# Altium schematic sheet Connectors 2.SchDoc("Connectors 2")
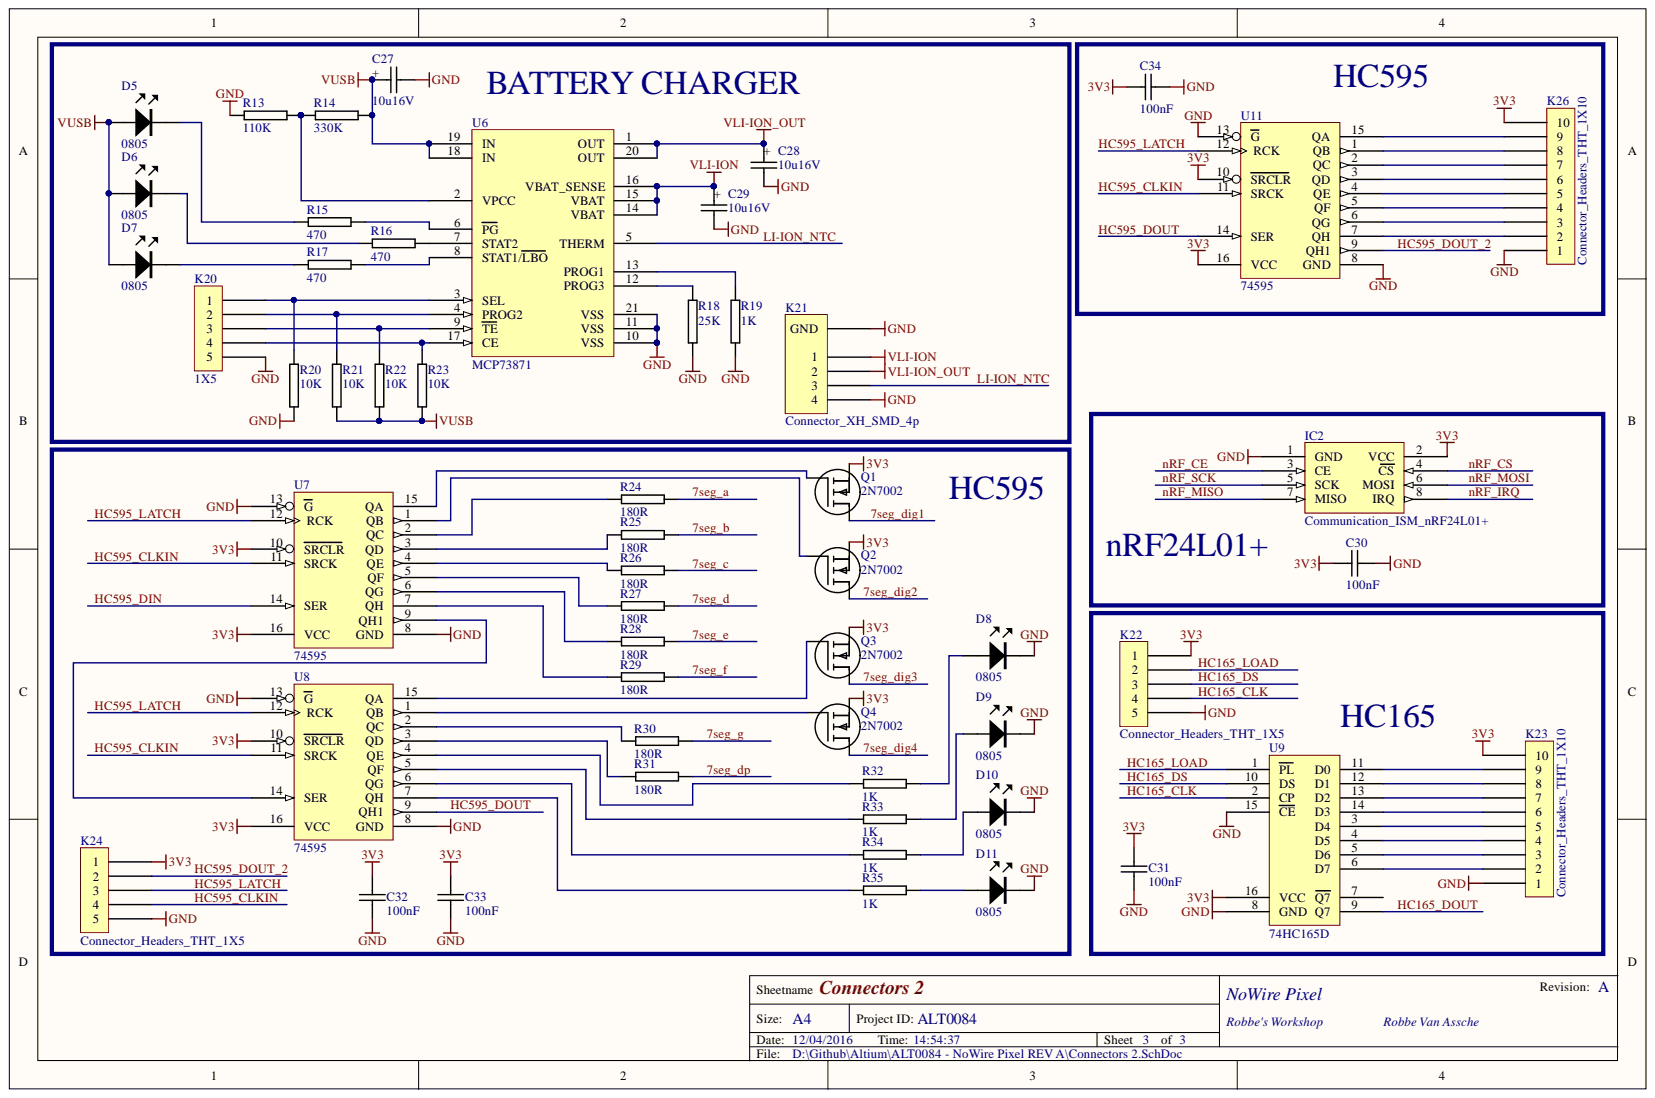
[diagram p0: Connectors 2.SchDoc]

## Parts
C27  10u16V  [1206C+_TANTAL-10u16V]
C28  10u16V  [1206C+_TANTAL-10u16V]
C29  10u16V  [1206C+_TANTAL-10u16V]
C30  100nF  [C0402]
C31  100nF  [C0402]
C32  100nF  [C0402]
C33  100nF  [C0402]
C34  100nF  [C0402]
D5  0805  [0805LED]
D6  0805  [0805LED]
D7  0805  [0805LED]
D8  0805  [0805LED]
D9  0805  [0805LED]
D10  0805  [0805LED]
D11  0805  [0805LED]
IC2  Communication_ISM_nRF24L01+  [nRF24L01+_COMBO]
K20  1X5  [HEADER-1X5]
K21  Connector_XH_SMD_4p  [2.54_4p_THT+SMD]
K22  Connector_Headers_THT_1X5  [HEADER-1X5]
K23  Connector_Headers_THT_1X10  [HEADER-1X10]
K24  Connector_Headers_THT_1X5  [HEADER-1X5]
K26  Connector_Headers_THT_1X10  [HEADER-1X10]
Q1  2N7002  [SOT23-GDS]
Q2  2N7002  Reel: Q2  [SOT23-GDS]
Q3  2N7002  [SOT23-GDS]
Q4  2N7002  [SOT23-GDS]
R13  110K  [R0402]
R14  330K  [R0402]
R15  470  [R0402]
R16  470  [R0402]
R17  470  [R0402]
R18  25K  [R0402]
R19  1K  [R0402]
R20  10K  [R0402]
R21  10K  [R0402]
R22  10K  [R0402]
R23  10K  [R0402]
R24  180R  [R0402]
R25  180R  [R0402]
R26  180R  [R0402]
R27  180R  [R0402]
R28  180R  [R0402]
R29  180R  [R0402]
R30  180R  [R0402]
R31  180R  [R0402]
R32  1K  [R0402]
R33  1K  [R0402]
R34  1K  [R0402]
R35  1K  [R0402]
U6  MCP73871  [QFN50P400X400X100_HS-21N]
U7  74595  [SO16]
U8  74595  [SO16]
U9  74HC165D  [SO16]
U11  74595  [SO16]

## Nets
3V3: C30.1 C31.1 C32.1 C33.1 C34.1 IC2.2(VCC) K22.1 K23.10 K24.1 K26.10 Q1.S Q2.S Q3.S Q4.S U7.10(SRCLR) U7.16(VCC) U8.10(SRCLR) U8.16(VCC) U9.16(VCC) U11.10(SRCLR) U11.16(VCC)
GND: C27.2 C28.2 C29.2 C30.2 C31.2 C32.2 C33.2 C34.2 D8.K D9.K D10.K D11.K IC2.1(GND) K20.5 K21.0(GND) K21.4 K22.5 K23.1 K24.5 K26.1 R13.1 R18.1 R19.2 R20.2 U6.10(VSS) U6.11(VSS) U6.21(VSS) U7.8(GND) U7.13(G) U8.8(GND) U8.13(G) U9.8(GND) U9.15(CE) U11.8(GND) U11.13(G)
VLI-ION: C29.1 K21.1 U6.14(VBAT) U6.15(VBAT) U6.16(VBAT_SENSE)
VLI-ION_OUT: C28.1 K21.2 U6.1(OUT) U6.20(OUT)
VUSB: C27.1 D5.A D6.A D7.A R14.2 R21.2 R22.2 R23.2 U6.18(IN) U6.19(IN)
7SEG_A: R24.2
7SEG_B: R25.2
7SEG_C: R26.2
7SEG_D: R27.2
7SEG_DIG1: Q1.D
7SEG_DIG2: Q2.D
7SEG_DIG3: Q3.D
7SEG_DIG4: Q4.D
7SEG_DP: R31.2
7SEG_E: R28.2
7SEG_F: R29.2
7SEG_G: R30.2
HC165_CLK: K22.4 U9.2(CP)
HC165_DOUT: U9.9(Q7)
HC165_DS: K22.3 U9.10(DS)
HC165_LOAD: K22.2 U9.1(PL)
HC595_CLKIN: K24.4 U7.11(SRCK) U8.11(SRCK) U11.11(SRCK)
HC595_DIN: U7.14(SER)
HC595_DOUT: U8.9(QH1) U11.14(SER)
HC595_DOUT_2: K24.2 U11.9(QH1)
HC595_LATCH: K24.3 U7.12(RCK) U8.12(RCK) U11.12(RCK)
LI-ION_NTC: K21.3 U6.5(THERM)
NRF_CE: IC2.3(CE)
NRF_CS: IC2.4(CS)
NRF_IRQ: IC2.8(IRQ)
NRF_MISO: IC2.7(MISO)
NRF_MOSI: IC2.6(MOSI)
NRF_SCK: IC2.5(SCK)
NetD5_K: D5.K R15.2
NetD6_K: D6.K R16.2
NetD7_K: D7.K R17.2
NetD8_A: D8.A R32.2
NetD9_A: D9.A R33.2
NetD10_A: D10.A R34.2
NetD11_A: D11.A R35.2
NetK20_1: K20.1 R20.1 U6.3(SEL)
NetK20_2: K20.2 R21.1 U6.4(PROG2)
NetK20_3: K20.3 R22.1 U6.9(TE)
NetK20_4: K20.4 R23.1 U6.17(CE)
NetK23_2: K23.2 U9.6(D7)
NetK23_3: K23.3 U9.5(D6)
NetK23_4: K23.4 U9.4(D5)
NetK23_5: K23.5 U9.3(D4)
NetK23_6: K23.6 U9.14(D3)
NetK23_7: K23.7 U9.13(D2)
NetK23_8: K23.8 U9.12(D1)
NetK23_9: K23.9 U9.11(D0)
NetK26_2: K26.2 U11.7(QH)
NetK26_3: K26.3 U11.6(QG)
NetK26_4: K26.4 U11.5(QF)
NetK26_5: K26.5 U11.4(QE)
NetK26_6: K26.6 U11.3(QD)
NetK26_7: K26.7 U11.2(QC)
NetK26_8: K26.8 U11.1(QB)
NetK26_9: K26.9 U11.15(QA)
NetQ1_G: Q1.G U7.15(QA)
NetQ2_G: Q2.G U7.1(QB)
NetQ3_G: Q3.G U8.15(QA)
NetQ4_G: Q4.G U8.1(QB)
NetR13_2: R13.2 R14.1 U6.2(VPCC)
NetR15_1: R15.1 U6.6(PG)
NetR16_1: R16.1 U6.7(STAT2)
NetR17_1: R17.1 U6.8(STAT1/LBO)
NetR18_2: R18.2 U6.12(PROG3)
NetR19_1: R19.1 U6.13(PROG1)
NetR24_1: R24.1 U7.2(QC)
NetR25_1: R25.1 U7.3(QD)
NetR26_1: R26.1 U7.4(QE)
NetR27_1: R27.1 U7.5(QF)
NetR28_1: R28.1 U7.6(QG)
NetR29_1: R29.1 U7.7(QH)
NetR30_1: R30.1 U8.2(QC)
NetR31_1: R31.1 U8.3(QD)
NetR32_1: R32.1 U8.4(QE)
NetR33_1: R33.1 U8.5(QF)
NetR34_1: R34.1 U8.6(QG)
NetR35_1: R35.1 U8.7(QH)
NetU7_9: U7.9(QH1) U8.14(SER)

Not connected: U9 7(Q7)

## Library data of the parts
C27-C29 = Passives_Capacitors_Tantalum_1206C-TANTAL SIZE A-10u16V: Component Kind: Standard; Library Name: RVA_Passives_Capacitors_Tantalum.SchLib
C30-C34 = Passives_Capacitors_0402_TBD: CAPACITOR 0402 CERAMIC; Component Kind: Standard; Library Name: RVA_Passives_Capacitors_0402.SchLib
D5-D11 = LED_SMD_0805: Component Kind: Standard; Library Name: RVA_LED_SMD_0805.SchLib
IC2 = Communication_ISM_nRF24L01+: nRF24L01+; Component Kind: Standard; Library Name: RVA_Communication_ISM.SchLib
K20 K22 K24 = Connector_Headers_THT_1X5: REEL: TH; Component Kind: Standard; Supplier 1: Digi-Key; Supplier Part Number 1: S1011E-36-ND; Library Name: RVA_Connector_Headers_THT.SchLib
K23 K26 = Connector_Headers_THT_1X10: REEL: TH; Component Kind: Standard; Supplier 1: Digi-Key; Supplier Part Number 1: S1011E-36-ND; Library Name: RVA_Connector_Headers_THT.SchLib
Q1 Q3 Q4 = 2N7002-SOT23: N CHANNEL MOSFET 2N7002 SOT23; Manufacturer: Fairchild Semiconductor; Current - Continuous Drain (Id) @ 25° C: 115mA; Drain to Source Voltage (Vdss): 60V; FET Feature: Logic Level Gate; FET Type: MOSFET N-Channel, Metal Oxide; Input Capacitance (Ciss) @ Vds: 50pF @ 25V; Power - Max: 200mW; Rds On (Max) @ Id, Vgs: 7.5 Ohm @ 500mA, 10V; Reel: Q2; Vgs(th) (Max) @ Id: 2.5V @ 250µA; Category: Discrete Semiconductor Products; Component Kind: Standard; Gate Charge (Qg) @ Vgs: -; Manufacturer Part Number: 2N7002; Mounting Type: Surface Mount; Package / Case: SOT-23-3, TO-236-3, Micro3™, SSD3, SST3; Packaging: Tape & Reel (TR); RoHS: RoHS Compliant; Supplier: Digi-Key; Supplier 1: Digi-Key; Supplier Part Number: 2N7002NTR-ND; Supplier Part Number 1: 2N7002NTR-ND; Library Name: RVA_Silicon Switch_Silicon_MOSFET_N-Channel.SchLib
Q2 = 2N7002-SOT23: N CHANNEL MOSFET 2N7002 SOT23; Manufacturer: Fairchild Semiconductor; Current - Continuous Drain (Id) @ 25° C: 115mA; Drain to Source Voltage (Vdss): 60V; FET Feature: Logic Level Gate; FET Type: MOSFET N-Channel, Metal Oxide; Input Capacitance (Ciss) @ Vds: 50pF @ 25V; Power - Max: 200mW; Rds On (Max) @ Id, Vgs: 7.5 Ohm @ 500mA, 10V; Vgs(th) (Max) @ Id: 2.5V @ 250µA; Category: Discrete Semiconductor Products; Component Kind: Standard; Gate Charge (Qg) @ Vgs: -; Manufacturer Part Number: 2N7002; Mounting Type: Surface Mount; Package / Case: SOT-23-3, TO-236-3, Micro3™, SSD3, SST3; Packaging: Tape & Reel (TR); RoHS: RoHS Compliant; Supplier: Digi-Key; Supplier 1: Digi-Key; Supplier Part Number: 2N7002NTR-ND; Supplier Part Number 1: 2N7002NTR-ND; Library Name: RVA_Silicon Switch_Silicon_MOSFET_N-Channel.SchLib
R13-R35 = Passives_Resistors_0402_TBD: RESISTOR 0402 VALUE TO BE DETERMINED; Component Kind: Standard; Library Name: RVA_Passives_Resistors_0402.SchLib
U6 = MCP73871: Battery charger; Component Kind: Standard; Library Name: RVA_Battery_SMD.SchLib
U7 U8 U11 = 74HC_SIPO_SMD_74595: 8-BIT SFT REG WIRTH OUTPUT LCH; Component Kind: Standard; Library Name: RVA_Logic_SIPO_SMD.SchLib
U9 = 74HC165D: Parallel in, Serial Out; Component Kind: Standard; Library Name: RVA_Logic_PISO_SMD.SchLib
K21 = Connector_XH_SMD_4p: Component Kind: Standard; Library Name: RVA_Connector_XH_SMD.SchLib
